# Altium schematic sheet Multi_Power_Amp15V.SchDoc
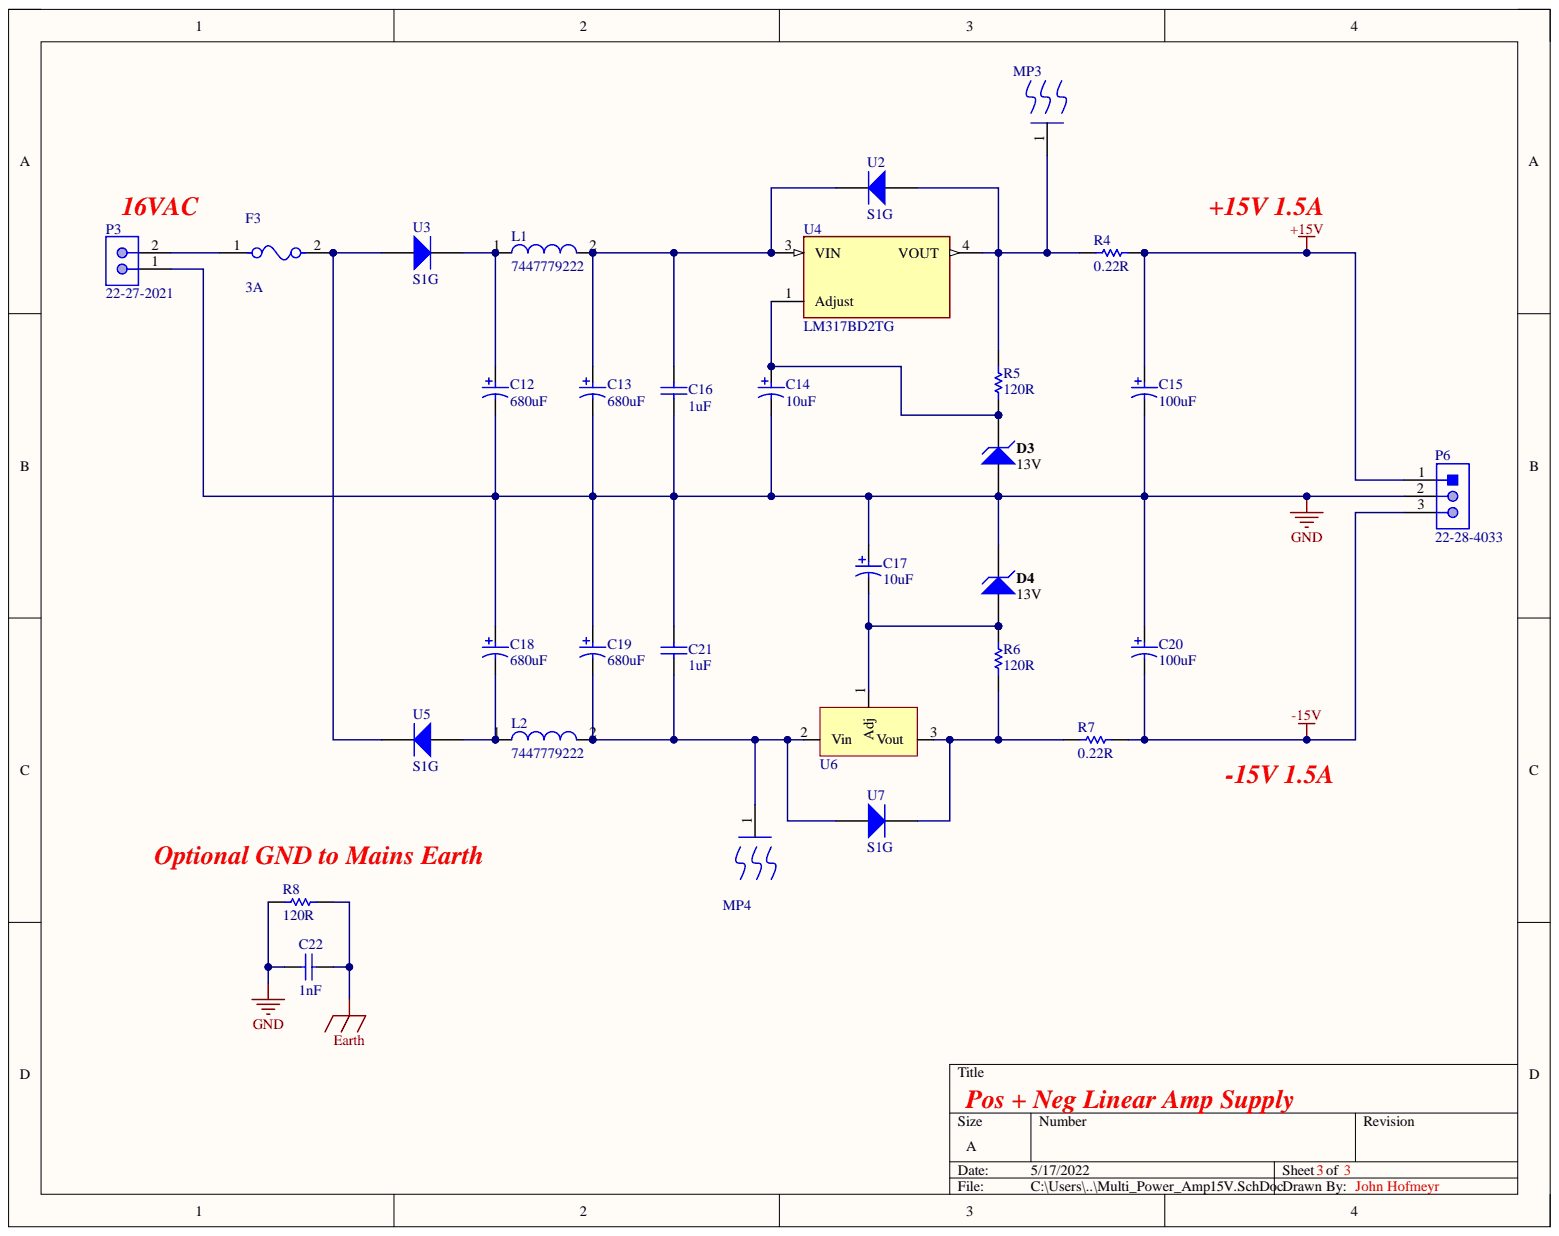
[diagram p0: Multi_Power_Amp15V.SchDoc]

## Parts
C12  680uF  [NIC-UHE-12.5x31.5-2_V]
C13  680uF  [NIC-UHE-12.5x31.5-2_V]
C14  10uF  [CAPPR55-200-1100X500X1200]
C15  100uF  [CAPPR65-350-1150X800X1250]
C16  1uF  [CAPC2013X140X50NL20T25]
C17  10uF  [CAPPR55-200-1100X500X1200]
C18  680uF  [NIC-UHE-12.5x31.5-2_V]
C19  680uF  [NIC-UHE-12.5x31.5-2_V]
C20  100uF  [CAPPR65-350-1150X800X1250]
C21  1uF  [CAPC2013X140X50NL20T25]
C22  1nF  [CAPC2013X94X50ML20T25]
D3  13V  [VISH-SOD-123-2_V]
D4  13V  [VISH-SOD-123-2_V]
F3  3A  [FP-0466-MFG]
L1  7447779222  [WE-PD-M-PB]
L2  7447779222  [WE-PD-M-PB]
MP3  573100D00010G  [FP-573100D00010G-MFG]  (value not drawn)
MP4  573100D00010G  [FP-573100D00010G-MFG]  (value not drawn)
P3  22-27-2021  [MOLX-22-27-2021_V]
P6  22-28-4033  [MOLX-22-28-4033_V]
R4  0.22R  [RESC3216X65X100NL20T15]
R5  120R  [RESC2013X50X40LL20T20]
R6  120R  [RESC2013X50X40LL20T20]
R7  0.22R  [RESC3216X65X100NL20T15]
R8  120R  [RESC2013X50X40LL20T20]
U2  S1G  [FAIR-DO-214AC-2_V]
U3  S1G  [FAIR-DO-214AC-2_V]
U4  LM317BD2TG  [FP-936-03-MFG]
U5  S1G  [FAIR-DO-214AC-2_V]
U6  LM337  [DPAK508P1420X432-3N]  (value not drawn)
U7  S1G  [FAIR-DO-214AC-2_V]

## Nets
+15V: C15.1 P6.1 R4.2
-15V: C20.2 P6.3 R7.2
Earth: C22.2 R8.1
GND: C12.2 C13.2 C14.2 C15.2 C16.1 C17.1 C18.1 C19.1 C20.1 C21.2 C22.1 D3.1 D4.2 P3.1 P6.2 R8.2
NetC12_1: C12.1 L1.1 U3.2
NetC13_1: C13.1 C16.2 L1.2 U2.2 U4.3
NetC14_1: C14.1 D3.2 R5.1 U4.1
NetC17_2: C17.2 D4.1 R6.2 U6.1
NetC18_2: C18.2 L2.1 U5.1
NetC19_2: C19.2 C21.1 L2.2 MP4.1 U6.2 U7.1
NetF3_1: F3.1 P3.2
NetF3_2: F3.2 U3.1 U5.2
NetMP3_1: MP3.1 R4.1 R5.2 U2.1 U4.4
NetR6_1: R6.1 R7.1 U6.3 U7.2

## Library data of the parts
C12 C13 C18 C19 = CMP-1253-00001-1: Aluminum Electrolytic Capacitor, 680 uF +/-20%, 50 V, -40 to 105 degC, 2-Pin THD, RoHS, Bulk; Manufacturer: Nichicon; PartNumber: UHE1H681MHD; Rated Ripple: 2310 mA; Rated Voltage: 50 V; Capacitance Tolerance: +/-20%; Component Kind: Standard; ComponentLink1Description: Manufacturer URL; ComponentLink1URL: http://www.nichicon.co.jp/; ComponentLink2Description: Datasheet; ComponentLink2URL: http://nichicon-us.com/english/products/pdfs/e-he.pdf; ComponentLink3Description: Package Specification; ComponentLink3URL: http://nichicon-us.com/english/products/pdfs/e-he.pdf; DatasheetVersion: Sep-13; Library Name: Altium Content Vault; Mounting Technology: Through Hole; Packing: Bulk; Rated Capacitance: 680 uF; RoHS: TRUE; Temperature Range: -40 to 105 degC
  C12: PackageDescription: 2-Pin Through Hole Device, Dia 12.5 mm, Body Length 31.5 m32m, Pin Spacing 5 mm
  C13 C18 C19: PackageDescription: 2-Pin Through Hole Device, Dia 12.5 mm, Body Length 31.5 mm, Pin Spacing 5 mm
C14 C17 = CMP-1665-00038-2: Aluminum Electrolytic Capacitor, 10 uF, +/- 20%, 50 V, -40 to 85 degC, 2-Pin THD, RoHS, Bulk; Manufacturer: Panasonic; Dielectric Material: Aluminium; Dissipation Factor: 0.12%; Lead Diameter: 0.5mm; Lead/Base Style: Straight; Leakage Current: 3uA; Life (Hours): 2000Hour; Ripple Current: 65mA; Voltage: 50V; Capacitance: 10uF; Case/Package: Radial; Component Kind: Standard; Datasheet URL: https://industrial.panasonic.com/cdbs/www-data/pdf/RDF0000/ABA0000C1218.pdf; Datasheet Version: Rev. 05, 10/2016; Diameter: 5mm; Height: 11mm; Height - Seated (Max): 0.472inch; Lead Free: LeadFree; Lead Pitch: 2mm; Length: 11mm; Library Name: Altium Content Vault; Manufacturer URL: http://www.panasonic.com/; Max Operating Temperature: 85degC; Min Operating Temperature: -40degC; Mount: ThroughHole; Number of Pins: 2; Package Description: 2-Pin Radial Lead, Dia 5 mm, Height 12 mm; Package Quantity: 200; Package Reference: CAPPR55-200-1100X500X1200; Packaging: Bulk; Radiation Hardening: No; REACH SVHC: NoSVHC; Ripple Current (AC): 65mA; RoHSCompliant: true; Termination: Radial; Tolerance: 20%; Voltage Rating: 50V; Voltage Rating (DC): 50V
C15 C20 = CMP-1665-00042-2: Aluminum Electrolytic Capacitor, 100 uF, +/- 20%, 50 V, -40 to 85 degC, 2-Pin THD, RoHS, Bulk; Manufacturer: Panasonic; Dielectric Material: Aluminium; Dissipation Factor: 0.12%; Lead Diameter: 0.6mm; Lead/Base Style: Straight; Leakage Current: 3uA; Life (Hours): 2000Hour; Polarity: Polar; Ripple Current: 250mA; Voltage: 50V; Capacitance: 100uF; Case/Package: Radial; Component Kind: Standard; Datasheet URL: https://industrial.panasonic.com/cdbs/www-data/pdf/RDF0000/ABA0000C1218.pdf; Datasheet Version: Rev. 05, 10/2016; Diameter: 8mm; Height: 11.5mm; Height - Seated (Max): 0.492inch; Lead Free: LeadFree; Lead Pitch: 3.5mm; Length: 11.5mm; Library Name: Altium Content Vault; Manufacturer URL: http://www.panasonic.com/; Max Operating Temperature: 85degC; Min Operating Temperature: -40degC; Mount: ThroughHole; Number of Pins: 2; Package Description: 2-Pin Radial Lead, Dia 8 mm, Height 12.5 mm; Package Quantity: 200; Package Reference: CAPPR65-350-1150X800X1250; Packaging: Bulk; Radiation Hardening: No; REACH SVHC: NoSVHC; Ripple Current (AC): 250mA; RoHSCompliant: true; Termination: Radial; Tolerance: 20%; Voltage Rating: 50V; Voltage Rating (DC): 50V
C16 C21 = CMP-1036-04762-2: Manufacturer: AVX Interconnect / Elco; Part Number: 08055D105KAT2A; Component Kind: Standard; Library Name: Altium Content Vault
C22 = CMP-1036-02979-2: Manufacturer: AVX Interconnect / Elco; Part Number: 08055C102KAT2A.; Component Kind: Standard; Library Name: Altium Content Vault
D3 D4 = CMP-0931-00041-1: Small Signal Zener Diode, 13 V +/- 5%, -55 to 155 degC, 2-Pin SOD-123, RoHS, Tape and Reel; Manufacturer: Vishay Semiconductor; PartNumber: MMSZ5243B-E3-08; Int. Construction: Single; Power Dissipation (mW): 500; Test Current (IZT1) (mA): 9.5; Tolerance: +/- 5%; Zener Impedance (IZT1) (Max.) (Ohm): 13; Component Kind: Standard; ComponentLink1Description: Manufacturer URL; ComponentLink1URL: http://www.vishay.com/; ComponentLink2Description: Datasheet; ComponentLink2URL: http://www.vishay.com/docs/85774/mmsz5225.pdf; ComponentLink3Description: Package Specification; ComponentLink3URL: http://www.vishay.com/docs/85774/mmsz5225.pdf; DatasheetVersion: Rev. 1.9; Library Name: Altium Content Vault; Mounting Technology: Surface Mount; Operating Temperature: -55 to 150 degC; PackageDescription: 2-Pin SMD, Body 2.7 x 1.55 mm, Height 1.35 mm; PackageReference: SOD-123-2; PackageVersion: Rev. 1.9; Packing: Tape and Reel; RoHS: TRUE; Zener Voltage (NOM.) (V): 13
F3 = CMP-08605-000054-1: FUSE BOARD MNT 3A 32VAC/VDC 1206; Manufacturer: Littelfuse; Manufacturer Part Number: 0466003.NR; Fuse Blow Speed: Fast; Fuse I2T: 0.576A2Sec; Fuse Interrupt Ac Current: 50A; Fuse Voltage Drop: 75.99mV; Max Fuse Ac Voltage: 32V; Resistance: 0.019Ω; Value: 0.019Ω; Automotive: No; Category: Fuse; Component Kind: Standard; ComponentLink2Description: Datasheet; ComponentLink2URL: https://www.littelfuse.com/~/media/electronics/datasheets/fuses/littelfuse_fuse_466_datasheet.pdf.pdf; Device Class L1: Circuit Protection; Device Class L2: Fuses; Device Class L3: unset; Digikey Description: FUSE BOARD MNT 3A 32VAC/VDC 1206; Fuse Current: 3A; Fuse Interrupt Dc Current: 50A; Height: 0.66mm; Lead Free: Yes; Library Name: Altium Content Vault; Max Fuse Dc Voltage: 32V; Mouser Description: Surface Mount Fuses 32V 3A VFA Slimline; Octopart: https://octopart.com/0466003.nr-littelfuse-565175; Package: 0466; RoHS: TRUE; Temperature: -55°C to +90°C; Temperature Range High: +90°C; Temperature Range Low: -55°C; Voltage Rating: 32V
L1 L2 = CMP-0227-00313-1: SMD-Shielded Power Inductor WE-PD, L = 220 µH; Inductance: 220 ÂµH; Component Kind: Standard; ComponentLink1Description: Manufacturer Link; ComponentLink1URL: http://www.we-online.com; ComponentLink2Description: Datasheet; ComponentLink2URL: http://www.we-online.de/katalog/media/pdf/7447779222.pdf; DatasheetVersion: ver 10, Jan-2008; Library Name: Altium Content Vault; PackageDescription: SMD Inductor, 2-Leads, Body 7.3 x 7.3 mm; PackageReference: WE-PD-M-PB; PackageVersion: 2008
MP3 MP4 = CMP-69313-000002-1: HEATSINK SMT D-PAK/TO-252 TIN; Manufacturer: Aavid Thermalloy; Mating Package: D‐Pak; Automotive: No; Category: UNK; Component Kind: Standard; ComponentLink2Description: Datasheet; ComponentLink2URL: https://www.shopaavid.com/images/Product/images/Board%20Level%20Cooling-Surface%20Mount%205731%20v1.pdf; Device Class L1: Electromechanical; Device Class L2: Thermal Management; Device Class L3: Heat Sinks; Digikey Description: HEATSINK SMT D-PAK/TO-252 TIN; Height: 10.16mm; Lead Free: Yes; Library Name: Altium Content Vault; Manufacturer Part Number: 573100D00010G; Mouser Description: Heat Sinks SLV HEATSINK TO-252; Octopart: https://octopart.com/573100d00010g-aavid+thermalloy-807578; Package: 573100D00010G; RoHS: TRUE
P3 = CMP-2000-05537-1: Male Header, Pitch 2.54 mm, 1 x 2 Position, Height 11.7 mm, Tail Length 3.56 mm, 0 to 75 degC, RoHS, Bulk; Manufacturer: Molex; PartNumber: 0022272021; Current - Max: 4A; No. of Positions: 2; No. of Rows: 1; Package Version: Rev. BC, 02/2012; Pitch: 2.54mm; Voltage - Max: 250V; Component Kind: Standard; Contact material: Tinplatedbrass; Current rating: 4A; Datasheet URL: http://www.molex.com/pdm_docs/sd/022272021_sd.pdf; Datasheet Version: Rev. F, 06/2009; Housing material: Nylon(UL94V-0); Library Name: Altium Content Vault; Manufacturer URL: http://www.molex.com/; Mounting Technology: ThroughHole; Operating temperature: 0°Cto+75°C; Orientation: Vertical; Package Description: 2-Leads Header, Body 5.08 x 5.8 mm, Pitch 2.54 mm; Package Reference: 22-27-2021; Voltage rating: 250V
P6 = CMP-1676-00001-5: Male Header, Pitch 2.54 mm, 1 x 3 Position, Height 8.38 mm, Tail Length 3.18 mm, RoHS, Bulk; Manufacturer: Molex; Current Rating: 4 A; Rows: 1; Voltage Rating: 250 V; Colour: Black; Component Kind: Standard; ComponentLink1Description: Manufacturer URL; ComponentLink1URL: http://www.molex.com/; ComponentLink2Description: Datasheet; ComponentLink2URL: http://www.molex.com/pdm_docs/sd/022284033_sd.pdf; Contact Finish: Gold; Contact Material: Brass; Contact Plating: Gold; DatasheetVersion: Rev. G, 01/2012; Height: 8.38 mm; Lead Pitch: 0.1 inch; Length: 7.62 mm; Library Name: Altium Content Vault; Max Operating Temperature: 75 degC; Min Operating Temperature: 0 degC; Mount: Through Hole; Mounting Technology: Through Hole; Package Quantity: 1; PackageDescription: Male Header, Pitch 2.54 mm, 1 x 3 Position, Height 8.38 mm, Tail Length 3.18 mm; PackageReference: 22-28-4033; Packaging: Bulk; Pins: 3; REACH SVHC: Unknown; RoHSCompliant: true
R4 R7 = CMP-2003-04721-1: Manufacturer: Susumu; Part Number: RL1632R-R220-F; Component Kind: Standard; Library Name: Altium Content Vault
R5 R6 R8 = CMP-2001-03236-1: Manufacturer: Susumu; Part Number: RR1220P-121-D; Component Kind: Standard; Library Name: Altium Content Vault
U2 U3 U5 U7 = CMP-2000-07011-1: General Purpose Rectifier, 1.4 W, -55 to 150 degC, 2-Pin DO-214AC, RoHS, Tape and Reel; Manufacturer: Fairchild Semiconductor; Average Rectified Current-Max: 1A; Forward Voltage: 1.1V; Power Dissipation Max: 1400mW; Reverse Current-Max: 1µA; Reverse Recovery Time-Max: 1.8µs; Reverse Voltage-Max [Vrrm]: 400V; Trr: 1800ns; Component Kind: Standard; Datasheet URL: https://www.fairchildsemi.com/datasheets/S1/S1G.pdf; Datasheet Version: Rev. 2.10, 05/2015; Library Name: Altium Content Vault; Manufacturer URL: https://www.fairchildsemi.com/; Mounting Technology: SurfaceMount; Package Description: 2-Pin Surface Mount Device, Body 4.375 x 2.725 mm; Package Reference: DO-214AC-2; Package URL: https://www.fairchildsemi.com/package-drawings/DO/DO214AC.pdf; PartNumber: S1G; VRRM Max: 400V
U4 = CMP-07128-000010-1: IC REG LINEAR POS ADJ 1.5A D2PAK; Manufacturer: ON Semiconductor; Max Junction Temp: +125°C; Max Supply Voltage: 40V; Number Of Outputs: 1; Output Type: AdjustableProgrammable; Output Voltage: 1.2-37V; Standoff Height: 0mm; Automotive: No; Category: IC; Component Kind: Standard; ComponentLink2Description: Datasheet; ComponentLink2URL: https://www.onsemi.com/pub/Collateral/LM317-D.PDF; Device Class L1: Integrated Circuits (ICs); Device Class L2: Power Management ICs; Device Class L3: Voltage Regulators - Linear; Digikey Description: IC REG LINEAR POS ADJ 1.5A D2PAK; Footprint Url: https://www.onsemi.com/pub/Collateral/936-03.PDF; Height: 4.826mm; Lead Free: Yes; Library Name: Altium Content Vault; Manufacturer Part Number: LM317BD2TG; Mouser Description: Linear Voltage Regulators 1.5A ADJ 1.2-37V Positive; Octopart: https://octopart.com/lm317bd2tg-on+semiconductor-1010532; Output Current: 1.5A; Package: 936-03; RoHS: TRUE; Temperature: -40°C to +125°C; Temperature Range High: +125°C; Temperature Range Low: -40°C
U6 = LM337D2TR4G: Component Kind: Standard; Library Name: DC-DC.SchLib; Supplier 1: Digi-Key; Supplier Part Number 1: LM337D2TR4GOSCT-ND
